# Altium schematic sheet Inverter Main.SchDoc
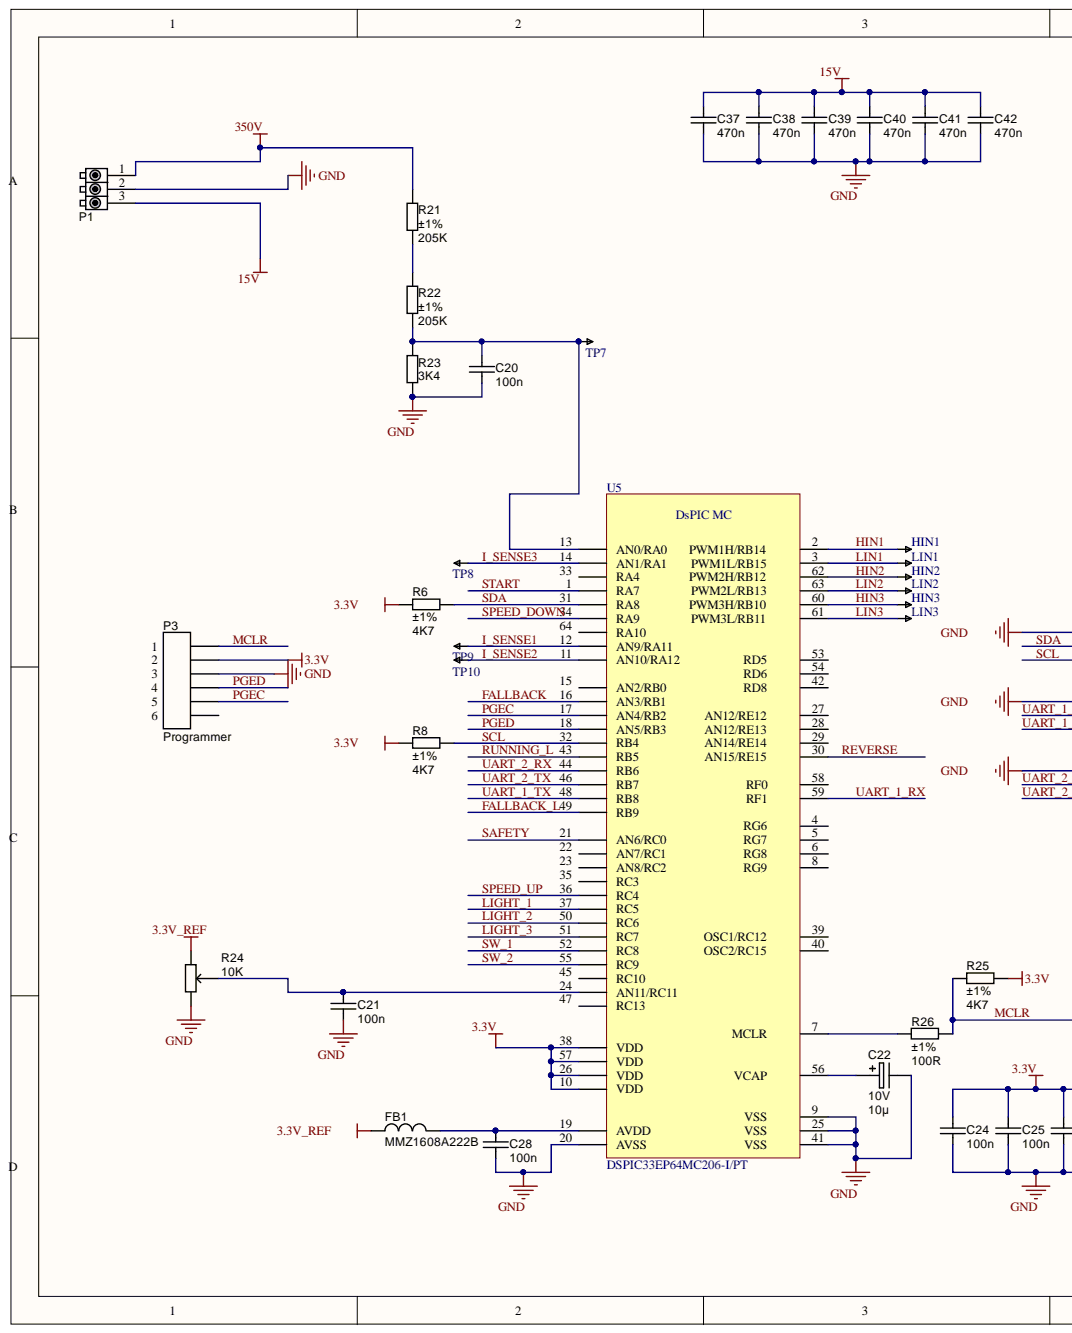
[diagram p0: Inverter Main.SchDoc, left]
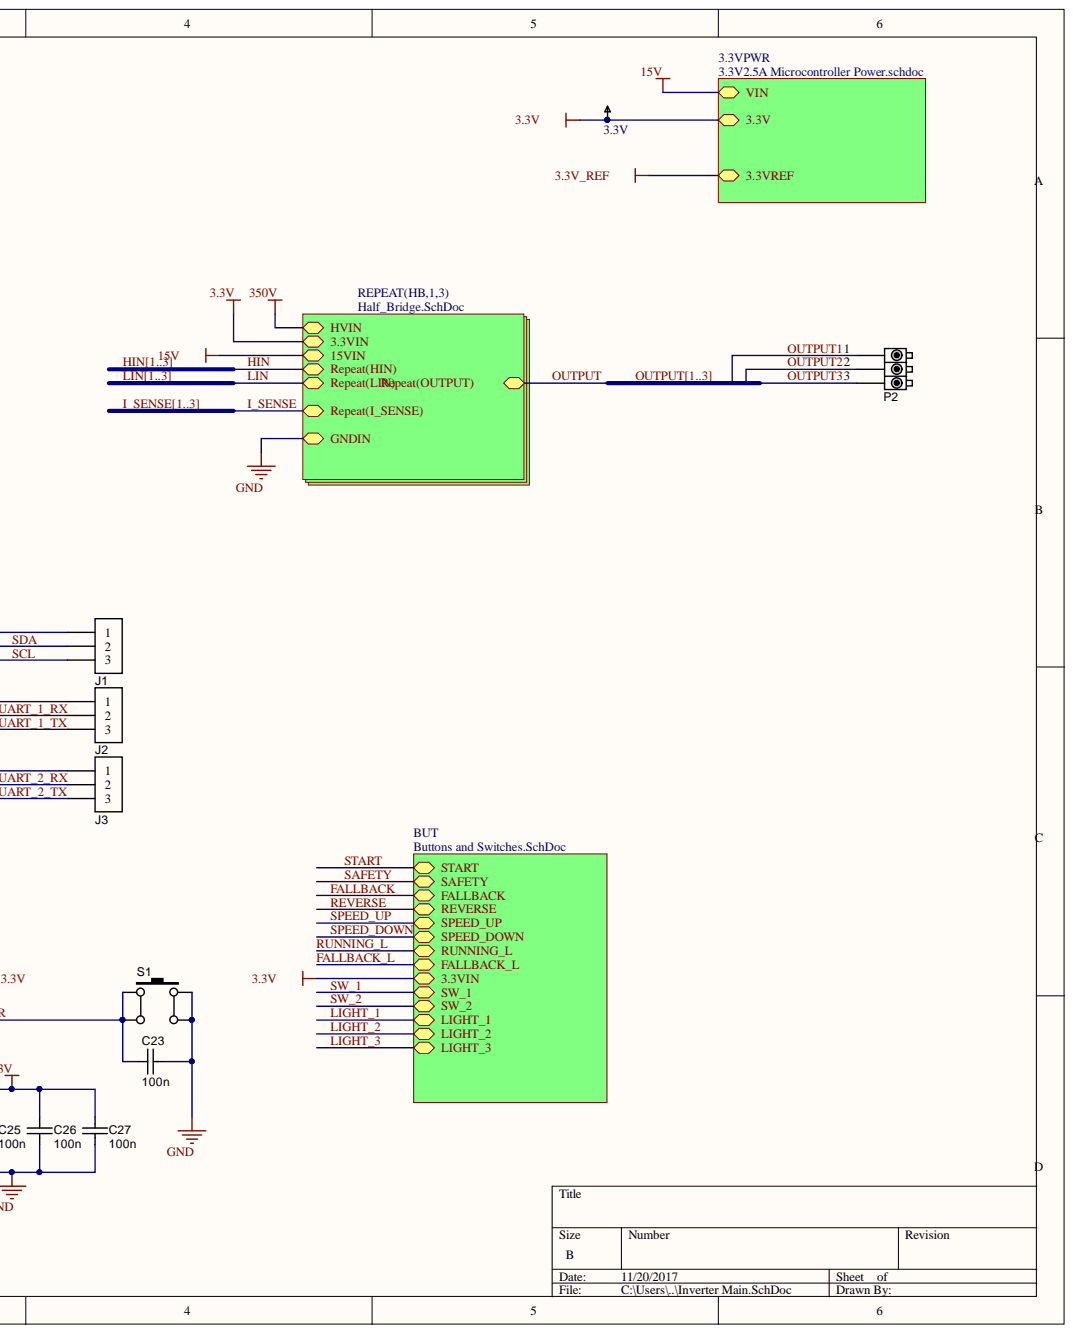
[diagram p1: Inverter Main.SchDoc, right]

## Parts
3.3V  TESTPOINT SMD 1206  [1206 Test Point]  (value not drawn)
C20  value 100n  [CAPC1608X90N]  (hidden comment: approved sub OK)
C21  value 100n  [CAPC1608X90N]  (hidden comment: approved sub OK)
C22  10µ  Ratings: 10V  [CAPMP2012X150USR]
C23  value 100n  [CAPC1608X90N]  (hidden comment: approved sub OK)
C24  value 100n  [CAPC1608X90N]  (hidden comment: approved sub OK)
C25  value 100n  [CAPC1608X90N]  (hidden comment: approved sub OK)
C26  value 100n  [CAPC1608X90N]  (hidden comment: approved sub OK)
C27  value 100n  [CAPC1608X90N]  (hidden comment: approved sub OK)
C28  value 100n  [CAPC1608X90N]  (hidden comment: approved sub OK)
C37  value 470n  [CAPC2012X135N]  (hidden comment: approved sub OK)
C38  value 470n  [CAPC2012X135N]  (hidden comment: approved sub OK)
C39  value 470n  [CAPC2012X135N]  (hidden comment: approved sub OK)
C40  value 470n  [CAPC2012X135N]  (hidden comment: approved sub OK)
C41  value 470n  [CAPC2012X135N]  (hidden comment: approved sub OK)
C42  value 470n  [CAPC2012X135N]  (hidden comment: approved sub OK)
FB1  Manufacturer Part Number 1: MMZ1608A222B  [FBC1608X95N]  (hidden comment: 2K2 @ 100 MHz)
HIN1  TESTPOINT SMD 1206  [1206 Test Point]  (value not drawn)
HIN2  TESTPOINT SMD 1206  [1206 Test Point]  (value not drawn)
HIN3  TESTPOINT SMD 1206  [1206 Test Point]  (value not drawn)
J1  JST_PH_B3B  [JST_PH_3_B3B]  (value not drawn)
J2  JST_PH_B3B  [JST_PH_3_B3B]  (value not drawn)
J3  JST_PH_B3B  [JST_PH_3_B3B]  (value not drawn)
LIN1  TESTPOINT SMD 1206  [1206 Test Point]  (value not drawn)
LIN2  TESTPOINT SMD 1206  [1206 Test Point]  (value not drawn)
LIN3  TESTPOINT SMD 1206  [1206 Test Point]  (value not drawn)
P1  3P_TERM BLOCK 796739-3  [TE 796739-3 3 Screw Terminal]  (value not drawn)
P2  3P_TERM BLOCK 796739-3  [TE 796739-3 3 Screw Terminal]  (value not drawn)
P3  Programmer  [HDR1X6]  (no BOM)
R6  value 4K7  Tolerance: ±1%  [RESC1608X55N]  (hidden comment: Thick Film)
R8  value 4K7  Tolerance: ±1%  [RESC1608X55N]  (hidden comment: Thick Film)
R21  value 205K  Tolerance: ±1%  [RESC2012X70N]  (hidden comment: Thick Film)
R22  value 205K  Tolerance: ±1%  [RESC2012X70N]  (hidden comment: Thick Film)
R23  value 3K4  [RESC1608X55N]  (hidden comment: Thick Film)
R24  value 10K  [POT_PDB12_BOURNS]  (hidden comment: RES_POT_10K_THT_PDB12-H4251-103BF)
R25  value 4K7  Tolerance: ±1%  [RESC1608X55N]  (hidden comment: Thick Film)
R26  value 100R  Tolerance: ±1%  [RESC1608X55N]  (hidden comment: Thick Film)
S1  TL3301AF160QJ  [SWITCH_TACT_JLEAD_6mm]  (value not drawn)
TP7  TESTPOINT SMD 1206  [1206 Test Point]  (value not drawn)
TP8  TESTPOINT SMD 1206  [1206 Test Point]  (value not drawn)
TP9  TESTPOINT SMD 1206  [1206 Test Point]  (value not drawn)
TP10  TESTPOINT SMD 1206  [1206 Test Point]  (value not drawn)
U5  uC_dsPIC33EP64MC206  [LQFP50P1200X1200X160-64]  (value not drawn)

## Nets
3.3V: 3.3V.1 C24.1 C25.1 C26.1 C27.1 P3.2 R6.1 R8.1 R25.2 U5.10(VDD) U5.26(VDD) U5.38(VDD) U5.57(VDD)
3.3V_REF: FB1.1 R24.1
15V: C37.1 C38.1 C39.1 C40.1 C41.1 C42.1 P1.3
350V: P1.1 R21.1
GND: C20.2 C21.2 C22.2 C23.2 C24.2 C25.2 C26.2 C27.2 C28.2 C37.2 C38.2 C39.2 C40.2 C41.2 C42.2 J1.1 J2.1 J3.1 P1.2 P3.3 R23.2 R24.3 S1.3 S1.4 U5.9 U5.20(AVSS) U5.25 U5.41
FALLBACK: U5.16(AN3/RB1)
FALLBACK_L: U5.49(RB9)
HIN1: HIN1.1 U5.2  [also labelled HIN]
HIN2: HIN2.1 U5.62  [also labelled HIN]
HIN3: HIN3.1 U5.60  [also labelled HIN]
I_SENSE1: TP9.1 U5.12(AN9/RA11)  [also labelled I_SENSE]
I_SENSE2: TP10.1 U5.11(AN10/RA12)  [also labelled I_SENSE]
I_SENSE3: TP8.1 U5.14(AN1/RA1)  [also labelled I_SENSE]
LIGHT_1: U5.37(RC5)
LIGHT_2: U5.50(RC6)
LIGHT_3: U5.51(RC7)
LIN1: LIN1.1 U5.3  [also labelled LIN]
LIN2: LIN2.1 U5.63  [also labelled LIN]
LIN3: LIN3.1 U5.61  [also labelled LIN]
MCLR: C23.1 P3.1 R25.1 R26.2 S1.1 S1.2
OUTPUT1: P2.1  [also labelled OUTPUT]
OUTPUT2: P2.2  [also labelled OUTPUT]
OUTPUT3: P2.3  [also labelled OUTPUT]
PGEC: P3.5 U5.17(AN4/RB2)
PGED: P3.4 U5.18(AN5/RB3)
REVERSE: U5.30
RUNNING_L: U5.43(RB5)
SAFETY: U5.21(AN6/RC0)
SCL: J1.3 R8.2 U5.32(RB4)
SDA: J1.2 R6.2 U5.31(RA8)
SPEED_DOWN: U5.34(RA9)
SPEED_UP: U5.36(RC4)
START: U5.1(RA7)
SW_1: U5.52(RC8)
SW_2: U5.55(RC9)
UART_1_RX: J2.2 U5.59
UART_1_TX: J2.3 U5.48(RB8)
UART_2_RX: J3.2 U5.44(RB6)
UART_2_TX: J3.3 U5.46(RB7)
NetC20_1: C20.1 R22.2 R23.1 TP7.1 U5.13(AN0/RA0)
NetC21_1: C21.1 R24.2 U5.24(AN11/RC11)
NetC22_1: C22.1 U5.56
NetC28_1: C28.1 FB1.2 U5.19(AVDD)
NetR21_2: R21.2 R22.1
NetR26_1: R26.1 U5.7

Not connected: P3 6; U5 4 5 6 8 15(AN2/RB0) 22(AN7/RC1) 23(AN8/RC2) 27 28 29 33(RA4) 35(RC3) 39 40 42 45(RC10) 47(RC13) 53 54 58 64(RA10)

## Library data of the parts
3.3V HIN1-HIN3 LIN1-LIN3 TP7-TP10 = TESTPOINT SMD 1206: Manufacturer: TE Connectivity AMP Connectors; Manufacturer Part Number: RCS-0C; Material - Insulation: No Insulation; Category: Test and Measurement; Color: Natural; Component Kind: Standard; ComponentLink1Description: http://www.te.com/commerce/DocumentDelivery/DDEController?Action=srchrtrv&DocNm=1773266&DocType=DS&DocLang=English; ComponentLink1URL: http://www.te.com/commerce/DocumentDelivery/DDEController?Action=srchrtrv&DocNm=1773266&DocType=DS&DocLang=English; Height: 0.049" (1.25mm); Library Name: PBL - Test Points.SCHLIB; Material - Body: -; Mounting Type: Surface Mount; Packaging: Cut Tape (CT); Part Status: Active; Plating: -; Pricing 1: 1=0.28, 10=0.261, 25=0.224, 50=0.1902, 100=0.1828, 250=0.16412, 500=0.15668, 1000=0.13056 (USD); RoHS: RoHS Compliant; Size / Dimension: 0.126" L x 0.063" W (3.20mm x 1.60mm); Stock 1: 28093; Supplier 1: Digi-Key; Supplier Part Number 1: A106143CT-ND; Type: PC Test Point
C20 C21 C23-C28 = CAPC_100n_0603_X7R_50V_10%: Capacitor SMD Cer 100nF 10% 50V X7R 0603; Manufacturer: Murata Electronics North America; Manufacturer Part Number: GRM188R71H104KA93D; Applications: General Purpose; Category: Capacitors; Component Kind: Standard; ComponentLink1Description: http://www.murata.com/~/media/webrenewal/support/library/catalog/products/capacitor/mlcc/c02e.pdf; ComponentLink1URL: http://www.murata.com/~/media/webrenewal/support/library/catalog/products/capacitor/mlcc/c02e.pdf; Features: -; Height - Seated (Max): -; Lead Spacing: -; Lead Style: -; Library Name: PBL - Capacitors.SCHLIB; Mounting Type: Surface Mount, MLCC; Operating Temperature: -55°C ~ 125°C; Package / Case: 0603 (1608 Metric); Packaging: Cut Tape (CT); Part Status: Active; Pricing 1: 1=0.12, 10=0.084, 100=0.0396, 500=0.02778, 1000=0.023 (USD); Ratings: -; RoHS: RoHS Compliant; Size / Dimension: 0.063" L x 0.031" W (1.60mm x 0.80mm); Stock 1: 21293840; Supplier 1: Digi-Key; Supplier Part Number 1: 490-1519-1-ND; Temperature Coefficient: X7R; Thickness (Max): 0.035" (0.90mm); Tolerance: ±10%; Voltage - Rated: 50V
C22 = CAPT_10u_0805_10V: Capacitor SMD Tantalum 10uF 20% 10V 0805; Manufacturer 1: AVX Corporation; Manufacturer Part Number 1: TAJP106M010RNJ; SCDType: spec; Component Kind: Standard; Library Name: PBL - Capacitors.SCHLIB; Material: Tantalum; Package / Case: 0805 (2012 metric); Supplier 1: Digi-Key; Supplier Part Number 1: 478-3281-1-ND; Tolerance: ±20%; Value: 10µ
C37-C42 = CAPC_470n_0805_X7R_50V_10%: 0.47µF ±10% 50V Ceramic Capacitor X7R 0805 (2012 Metric); Manufacturer: Murata Electronics North America; Manufacturer Part Number: GRM21BR71H474KA88K; Applications: General Purpose; Category: Capacitors; Component Kind: Standard; ComponentLink1Description: http://search.murata.co.jp/Ceramy/image/img/A01X/G101/ENG/GRM21BR71H474KA88-01.pdf; ComponentLink1URL: http://search.murata.co.jp/Ceramy/image/img/A01X/G101/ENG/GRM21BR71H474KA88-01.pdf; Features: -; Height - Seated (Max): -; Lead Spacing: -; Lead Style: -; Library Name: PBL - Capacitors.SCHLIB; Mounting Type: Surface Mount, MLCC; Operating Temperature: -55°C ~ 125°C; Package / Case: 0805 (2012 Metric); Packaging: Cut Tape (CT); Part Status: Active; Pricing 1: 1=0.35, 10=0.244, 100=0.1465, 500=0.10986, 1000=0.09278, 2500=0.08789, 5000=0.08301 (USD); Ratings: -; RoHS: RoHS Compliant; Size / Dimension: 0.079" L x 0.049" W (2.00mm x 1.25mm); Stock 1: 76560; Supplier 1: Digi-Key; Supplier Part Number 1: 490-8319-1-ND; Temperature Coefficient: X7R; Thickness (Max): 0.053" (1.35mm); Tolerance: ±10%; Voltage - Rated: 50V
FB1 = FERRITE_2K2-100MHz_0603: Ferrite bead 2.2K Ohm at 100 MHz 200mA 0603 SMD; Manufacturer 1: TDK Corporation; DC Resistance (DCR): 800 mOhm Max; Filter Type: Differential Mode - Single; Impedance @ Frequency: 2200 Ohm @ 100MHz; Ratings: 200 mA; 800 mOhm DC; SCDType: source; Component Kind: Standard; ComponentLink1Description: http://www.tdk.co.jp/tefe02/e9412_mmz.pdf; ComponentLink1URL: http://www.tdk.co.jp/tefe02/e9412_mmz.pdf; Current Rating: 200mA; Height (Max): 0.037" (0.95mm); Library Name: PBL - Inductors.SCHLIB; Mounting Type: Surface Mount; Package / Case: 0603 (1608 Metric); RoHS 1: RoHS Compliant; Size / Dimension: 0.063" L x 0.031" W (1.60mm x 0.80mm); Supplier 1: Digi-Key; Supplier Part Number 1: 445-8671-1-ND; Value: 2K2 @ 100 MHz
J1-J3 = JST_PH_B3B: 2mm Pitch; Component Kind: Standard; Library Name: PBL - Connectors.SCHLIB; Supplier 1: Digi-Key; Supplier Part Number 1: 455-1705-ND
P1 P2 = 3P_TERM BLOCK 796739-3: 3 Position Wire to Board Terminal Block Horizontal with Board 0.375" (9.53mm) Through Hole; Manufacturer: TE Connectivity AMP Connectors; Clamp Material - Plating: Brass - Nickel Plated; Current: 30A; Number of Levels: 1; Positions Per Level: 3; Screw Thread: M3; Torque - Screw: 0.79 Nm (7.0 Lb-In); Voltage: 600V; Wire Gauge: 10-30 AWG; Wire Termination: Screw - Rising Cage Clamp; Category: Connectors, Interconnects; Color: Green; Component Kind: Standard; ComponentLink1Description: http://www.te.com/commerce/DocumentDelivery/DDEController?Action=srchrtrv&DocNm=796739&DocType=Customer+Drawing&DocLang=English; ComponentLink1URL: http://www.te.com/commerce/DocumentDelivery/DDEController?Action=srchrtrv&DocNm=796739&DocType=Customer+Drawing&DocLang=English; Contact Material - Plating: -; Features: Interlocking (Side); Housing Material: Polyamide (PA66), Nylon 6/6; Library Name: PBL - Connectors.SCHLIB; Manufacturer Part Number: 796739-3; Mating Orientation: Horizontal with Board; Mounting Type: Through Hole; Operating Temperature: -; Packaging: Tray; Part Status: Active; Pitch: 0.375" (9.53mm); Pricing 1: 1=5.17, 10=3.942, 25=3.692, 50=3.2816, 100=3.2104, 250=2.96064, 500=2.6753, 1000=2.37209, 2500=2.274 (USD); RoHS: RoHS Compliant; Screw Material - Plating: Brass - Nickel Plated; Stock 1: 1080; Supplier 1: Digi-Key; Supplier Part Number 1: A98274-ND
P3 = Header6P: Header, 6-pin; Library Name: PBL - Connectors.SCHLIB
R6 R8 R25 = RES_4K7_0603_1%: Resistor SMD chip 4.7K Ohm 0.1W 1% 0603; Manufacturer: Yageo; Manufacturer Part Number: RC0603FR-074K7L; Ratings: 1/10W; SCDType: undef; Component Kind: Standard; Library Name: PBL - Resistors.SCHLIB; Package / Case: 0603 (1608 Metric); Supplier 1: Digi-Key; Supplier Part Number 1: 311-4.70KHRCT-ND
R21 R22 = RES_205K_0805_1%: Resistor SMD chip 205k Ohm 0.125W 1% 0805; Manufacturer 1: Vishay/Dale; Manufacturer Part Number 1: CRCW0805205KFKEA; Ratings: 1/8W; SCDType: spec; Component Kind: Standard; Library Name: PBL - Resistors.SCHLIB; Package / Case: 0805 (2012 metric); Supplier 1: Digi-Key; Supplier Part Number 1: 541-205KCCT-ND
R23 = RES_3K4_0603_1%: Resistor SMD chip 3.4k Ohm 0.1W 1% 0603; Manufacturer: Panasonic Electronic Components; Manufacturer Part Number: ERJ-3EKF3401V; Power (Watts): 0.1W, 1/10W; Temperature Coefficient: ±100ppm/°C; Category: Resistors; Component Kind: Standard; ComponentLink1Description: https://industrial.panasonic.com/cdbs/www-data/pdf/RDA0000/AOA0000C304.pdf; ComponentLink1URL: https://industrial.panasonic.com/cdbs/www-data/pdf/RDA0000/AOA0000C304.pdf; Composition: Thick Film; Failure Rate: -; Features: Automotive AEC-Q200; Height - Seated (Max): 0.022" (0.55mm); Library Name: PBL - Resistors.SCHLIB; Operating Temperature: -55°C ~ 155°C; Package / Case: 0603 (1608 Metric); Packaging: Cut Tape (CT); Part Status: Active; Pricing 1: 1=0.1, 10=0.021, 100=0.0087, 1000=0.00389, 2500=0.00338 (USD); RoHS: RoHS Compliant; Size / Dimension: 0.063" L x 0.031" W (1.60mm x 0.80mm); Stock 1: 108430; Supplier 1: Digi-Key; Supplier Device Package: 0603; Supplier Part Number 1: P3.40KHCT-ND; Tolerance: ±1%
R24 = RES_POT_10K_THT_PDB12-H4251-103BF: 10k Ohm 1 Gang Linear Through Hole Potentiometer None 1 Turn Carbon 0.08W PC Pins, Board Locks; Manufacturer: Bourns Inc.; Actuator Diameter: 0.236" (6.00mm); Actuator Length: 0.984" (25.00mm); Actuator Type: Flatted; Adjustment Type: Top Adjustment; Rotation: 300°; Tolerance: ±20%; Built in Switch: None; Bushing Thread: -; Category: Potentiometers, Variable Resistors; Component Kind: Standard; ComponentLink1Description: http://www.bourns.com/docs/Product-Datasheets/PDB12.pdf; ComponentLink1URL: http://www.bourns.com/docs/Product-Datasheets/PDB12.pdf; Library Name: PBL - Resistors.SCHLIB; Manufacturer Part Number: PDB12-H4251-103BF; Mounting Type: Through Hole; Packaging: Bulk; Part Status: Active; Power (Watts): 0.08W; Pricing 1: 1=0.92, 10=0.81, 25=0.7292, 50=0.702, 100=0.675, 250=0.63452, 500=0.54, 1000=0.4995, 5000=0.4104 (USD); Resistance (Ohms): 10k; Resistive Material: Carbon; RoHS: RoHS Compliant; Stock 1: 946; Supplier 1: Digi-Key; Supplier Part Number 1: PDB12-H4251-103BF-ND; Taper: Linear; Temperature Coefficient: -; Termination Style: PC Pins, Board Locks
R26 = RES_100R_0603_1%: Resistor SMD chip 100 Ohm 0.1W 1% 0603; Manufacturer 1: Yageo; Manufacturer Part Number 1: RC0603FR-07100RL; Ratings: 1/10 W; SCDType: spec; Value: 100R; Component Kind: Standard; ComponentLink1Description: http://industrial.panasonic.com/www-cgi/jvcr13pz.cgi?E+PZ+3+AOA0002+ERJ3EKF1000V+7+WW; ComponentLink1URL: http://industrial.panasonic.com/www-cgi/jvcr13pz.cgi?E+PZ+3+AOA0002+ERJ3EKF1000V+7+WW; Library Name: PBL - Resistors.SCHLIB; Manufacturer 2: Panasonic - ECG; Manufacturer Part Number 2: ERJ-3EKF1000V; Material: Thick Film; Package / Case: 0603 (1608 Metric); Supplier 1: Digi-Key; Supplier 2: Digi-Key; Supplier Part Number 1: 311-100HRCT-ND; Supplier Part Number 2: P100HTR-ND
S1 = TACT_SWITCH 6x6 JLEAD TL3301AF160QJ: Manufacturer: E-Switch; Actuator Height off PCB, Vertical: 5.00mm; Actuator Material: Polyamide (PA), Nylon; Actuator Orientation: Top Actuated; Actuator Type: Standard; Circuit: SPST-NO; Contact Rating @ Voltage: 0.05A @ 12VDC; Illumination: Non-Illuminated; Mechanical Life: 100,000 Cycles; Operating Force: 160gf; Outline: 6.00mm x 6.00mm; Switch Function: Off-Mom; Switch Travel: 0.25mm; Actuator Length, Right Angle: -; Category: Switches; Component Kind: Standard; ComponentLink1Description: https://www.e-switch.com/system/asset/product_line/data_sheet/158/TL3301.pdf; ComponentLink1URL: https://www.e-switch.com/system/asset/product_line/data_sheet/158/TL3301.pdf; Description: TACT_SWITCH 6x6 Jlead TL3301AF160QJ; Features: -; Illumination Type, Color: -; Illumination Voltage (Nominal): -; Ingress Protection: -; Library Name: PBL - Switches.SCHLIB; Manufacturer Part Number: TL3301AF160QJ; Mounting Type: Surface Mount; Operating Temperature: -20°C ~ 70°C; Packaging: Cut Tape (CT); Part Status: Active; Pricing 1: 1=0.43, 10=0.41, 25=0.4024, 50=0.387, 100=0.334, 250=0.3036, 500=0.28842 (USD); RoHS: RoHS Compliant; Stock 1: 12724; Supplier 1: Digi-Key; Supplier Part Number 1: EG4374CT-ND; Termination Style: J Lead
U5 = uC_dsPIC33EP64MC206: DSPIC33EP64MC206-I/PT; Manufacturer: Microchip Technology; Connectivity: I²C, IrDA, LIN, QEI, SPI, UART/USART; Core Processor: dsPIC; Core Size: 16-Bit; Data Converters: A/D 16x10b/12b; Oscillator Type: Internal; Peripherals: Brown-out Detect/Reset, DMA, Motor Control PWM, POR, PWM, WDT; Program Memory Size: 64KB (22K x 24); Program Memory Type: FLASH; RAM Size: 4K x 16; Speed: 70 MIPs; Voltage - Supply (Vcc/Vdd): 3 V ~ 3.6 V; Category: Integrated Circuits (ICs); Component Kind: Standard; ComponentLink1Description: http://www.microchip.com/mymicrochip/filehandler.aspx?ddocname=en556386; ComponentLink1URL: http://www.microchip.com/mymicrochip/filehandler.aspx?ddocname=en556386; EEPROM Size: -; Library Name: PBL - Integrated Circuits.SCHLIB; Manufacturer Part Number: DSPIC33EP64MC206-I/PT; Operating Temperature: -40°C ~ 85°C (TA); Package / Case: 64-TQFP; Packaging: Tray; Part Status: Active; Pricing 1: 1=3.03, 25=2.7824, 100=2.52 (USD); RoHS: RoHS Compliant; Stock 1: 6; Supplier 1: Digi-Key; Supplier Device Package: 64-TQFP (10x10); Supplier Part Number 1: DSPIC33EP64MC206-I/PT-ND
